# Altium schematic sheet USB_charger.SchDoc(USB_charger)
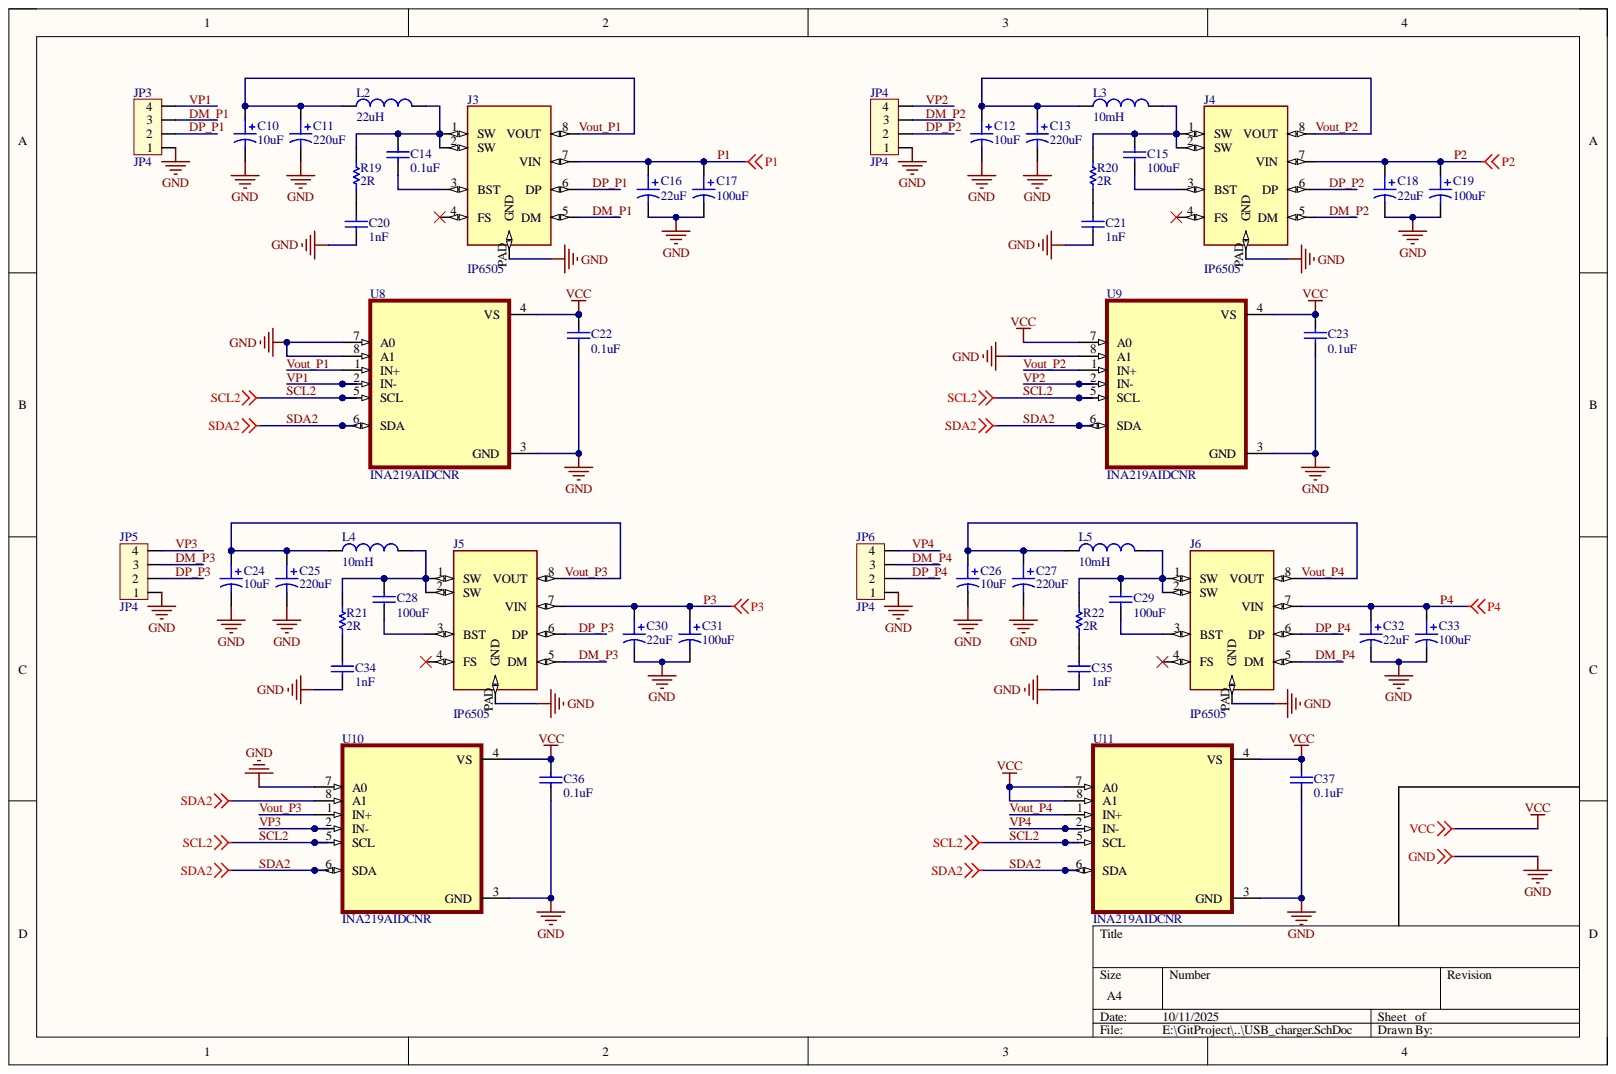
[diagram p0: USB_charger.SchDoc]

## Parts
C10  value 10uF  [RADIAL C]  (hidden comment: Cap Pol)
C11  value 220uF  [RADIAL C]  (hidden comment: Cap Pol)
C12  value 10uF  [RADIAL C]  (hidden comment: Cap Pol)
C13  value 220uF  [RADIAL C]  (hidden comment: Cap Pol)
C14  value 0.1uF  [C-NP-0805]  (hidden comment: Cap Non-pol)
C15  value 100uF  [C-NP-0805]  (hidden comment: Cap Non-pol)
C16  value 22uF  [RADIAL C]  (hidden comment: Cap Pol)
C17  value 100uF  [RADIAL C]  (hidden comment: Cap Pol)
C18  value 22uF  [RADIAL C]  (hidden comment: Cap Pol)
C19  value 100uF  [RADIAL C]  (hidden comment: Cap Pol)
C20  value 1nF  [C-NP-0805]  (hidden comment: Cap Non-pol)
C21  value 1nF  [C-NP-0805]  (hidden comment: Cap Non-pol)
C22  value 0.1uF  [C-NP-0805]  (hidden comment: Cap Non-pol)
C23  value 0.1uF  [C-NP-0805]  (hidden comment: Cap Non-pol)
C24  value 10uF  [RADIAL C]  (hidden comment: Cap Pol)
C25  value 220uF  [RADIAL C]  (hidden comment: Cap Pol)
C26  value 10uF  [RADIAL C]  (hidden comment: Cap Pol)
C27  value 220uF  [RADIAL C]  (hidden comment: Cap Pol)
C28  value 100uF  [C-NP-0805]  (hidden comment: Cap Non-pol)
C29  value 100uF  [C-NP-0805]  (hidden comment: Cap Non-pol)
C30  value 22uF  [RADIAL C]  (hidden comment: Cap Pol)
C31  value 100uF  [RADIAL C]  (hidden comment: Cap Pol)
C32  value 22uF  [RADIAL C]  (hidden comment: Cap Pol)
C33  value 100uF  [RADIAL C]  (hidden comment: Cap Pol)
C34  value 1nF  [C-NP-0805]  (hidden comment: Cap Non-pol)
C35  value 1nF  [C-NP-0805]  (hidden comment: Cap Non-pol)
C36  value 0.1uF  [C-NP-0805]  (hidden comment: Cap Non-pol)
C37  value 0.1uF  [C-NP-0805]  (hidden comment: Cap Non-pol)
J3  IP6505  [ESOP-8_W_PAD]
J4  IP6505  [ESOP-8_W_PAD]
J5  IP6505  [ESOP-8_W_PAD]
J6  IP6505  [ESOP-8_W_PAD]
JP3  JP4  [CON4]
JP4  JP4  [CON4]
JP5  JP4  [CON4]
JP6  JP4  [CON4]
L2  value 22uH  [INDUCTOR 12.5x12.5]  (hidden comment: Inductor)
L3  value 10mH  [INDUCTOR 12.5x12.5]  (hidden comment: Inductor)
L4  value 10mH  [INDUCTOR 12.5x12.5]  (hidden comment: Inductor)
L5  value 10mH  [INDUCTOR 12.5x12.5]  (hidden comment: Inductor)
R19  value 2R  [R-0805]  (hidden comment: RESISTOR)
R20  value 2R  [R-0805]  (hidden comment: RESISTOR)
R21  value 2R  [R-0805]  (hidden comment: RESISTOR)
R22  value 2R  [R-0805]  (hidden comment: RESISTOR)
U8  INA219AIDCNR  [SOT65P280X145-8N]
U9  INA219AIDCNR  [SOT65P280X145-8N]
U10  INA219AIDCNR  [SOT65P280X145-8N]
U11  INA219AIDCNR  [SOT65P280X145-8N]

## Nets
GND: C10.2 C11.2 C12.2 C13.2 C16.2 C17.2 C18.2 C19.2 C20.1 C21.1 C22.1 C23.1 C24.2 C25.2 C26.2 C27.2 C30.2 C31.2 C32.2 C33.2 C34.1 C35.1 C36.1 C37.1 J3.PAD(GND) J4.PAD(GND) J5.PAD(GND) J6.PAD(GND) JP3.1 JP4.1 JP5.1 JP6.1 U8.3(GND) U8.7(A0) U8.8(A1) U9.3(GND) U9.8(A1) U10.3(GND) U10.7(A0) U11.3(GND)
VCC: C22.2 C23.2 C36.2 C37.2 U8.4(VS) U9.4(VS) U9.7(A0) U10.4(VS) U11.4(VS) U11.7(A0) U11.8(A1)
DM_P1: J3.5(DM) JP3.3
DM_P2: J4.5(DM) JP4.3
DM_P3: J5.5(DM) JP5.3
DM_P4: J6.5(DM) JP6.3
DP_P1: J3.6(DP) JP3.2
DP_P2: J4.6(DP) JP4.2
DP_P3: J5.6(DP) JP5.2
DP_P4: J6.6(DP) JP6.2
P1: C16.1 C17.1 J3.7(VIN)
P2: C18.1 C19.1 J4.7(VIN)
P3: C30.1 C31.1 J5.7(VIN)
P4: C32.1 C33.1 J6.7(VIN)
SCL2: U8.5(SCL) U9.5(SCL) U10.5(SCL) U11.5(SCL)
SDA2: U8.6(SDA) U9.6(SDA) U10.6(SDA) U10.8(A1) U11.6(SDA)
Vout_P1: C10.1 C11.1 J3.8(VOUT) L2.1 U8.1(IN+)
Vout_P2: C12.1 C13.1 J4.8(VOUT) L3.1 U9.1(IN+)
Vout_P3: C24.1 C25.1 J5.8(VOUT) L4.1 U10.1(IN+)
Vout_P4: C26.1 C27.1 J6.8(VOUT) L5.1 U11.1(IN+)
VP1: JP3.4 U8.2(IN-)
VP2: JP4.4 U9.2(IN-)
VP3: JP5.4 U10.2(IN-)
VP4: JP6.4 U11.2(IN-)
NetC14_1: C14.1 J3.3(BST)
NetC14_2: C14.2 J3.1(SW) J3.2(SW) L2.2 R19.2
NetC15_1: C15.1 J4.3(BST)
NetC15_2: C15.2 J4.1(SW) J4.2(SW) L3.2 R20.2
NetC20_2: C20.2 R19.1
NetC21_2: C21.2 R20.1
NetC28_1: C28.1 J5.3(BST)
NetC28_2: C28.2 J5.1(SW) J5.2(SW) L4.2 R21.2
NetC29_1: C29.1 J6.3(BST)
NetC29_2: C29.2 J6.1(SW) J6.2(SW) L5.2 R22.2
NetC34_2: C34.2 R21.1
NetC35_2: C35.2 R22.1

Not connected: J3 4(FS); J4 4(FS); J5 4(FS); J6 4(FS)

## Library data of the parts
C10-C13 C16-C19 C24-C27 C30-C33 = Cap Pol: Polarized Capacitor; Component Kind: Standard; LatestRevisionDate: 17-Jul-2002; LatestRevisionNote: Re-released for DXP Platform.; PackageReference: POLAR0.8; Published: 8-Jun-2000; Library Name: Kiet.IntLib; Publisher: Altium Limited
C14 C15 C20-C23 C28 C29 C34-C37 = Cap Non-pol: Non-polarized Capacitor; Component Kind: Standard; LatestRevisionDate: 17-Jul-2002; LatestRevisionNote: Re-released for DXP Platform.; PackageReference: RAD-0.3; Published: 8-Jun-2000; Library Name: Kiet.IntLib; Publisher: Altium Limited
J3-J6 = IP6505: 24W Max Synchronous-Rectified Buck Converter; MF: Injoinic; Check_prices: https://www.snapeda.com/parts/IP6505/Injoinic/view-part/?ref=eda; Component Kind: Standard; Library Name: IP6505.IntLib; MP: IP6505; Package: None; SnapEDA_Link: https://www.snapeda.com/parts/IP6505/Injoinic/view-part/?ref=snap
JP3-JP6 = Con4: Connector 4; Component Kind: Standard; Value: Connector 4; Library Name: Kiet.IntLib
U8-U11 = INA219AIDCNR: 26-V 12-bit I2C output digital power monitor; MF: Texas Instruments; Check_prices: https://www.snapeda.com/parts/INA219AIDCNR/Texas+Instruments/view-part/?ref=eda; Component Kind: Standard; Library Name: INA219AIDCNR.IntLib; MP: INA219AIDCNR; Package: SOT-23-8 Texas Instruments; SnapEDA_Link: https://www.snapeda.com/parts/INA219AIDCNR/Texas+Instruments/view-part/?ref=snap
L2-L5 = Inductor: Component Kind: Standard; Description: Inductor; Library Name: thuvienhoang.IntLib
R19-R22 = RESISTOR: Component Kind: Standard; Description: Resistor; LatestRevisionDate: 17-Jul-2002; LatestRevisionNote: Re-released for DXP Platform.; PackageReference: AXIAL-0.3; Published: 8-Jun-2000; Library Name: Kiet.IntLib; Publisher: Altium Limited
